# Altium schematic sheet [08]Debug Headers and Support.SchDoc
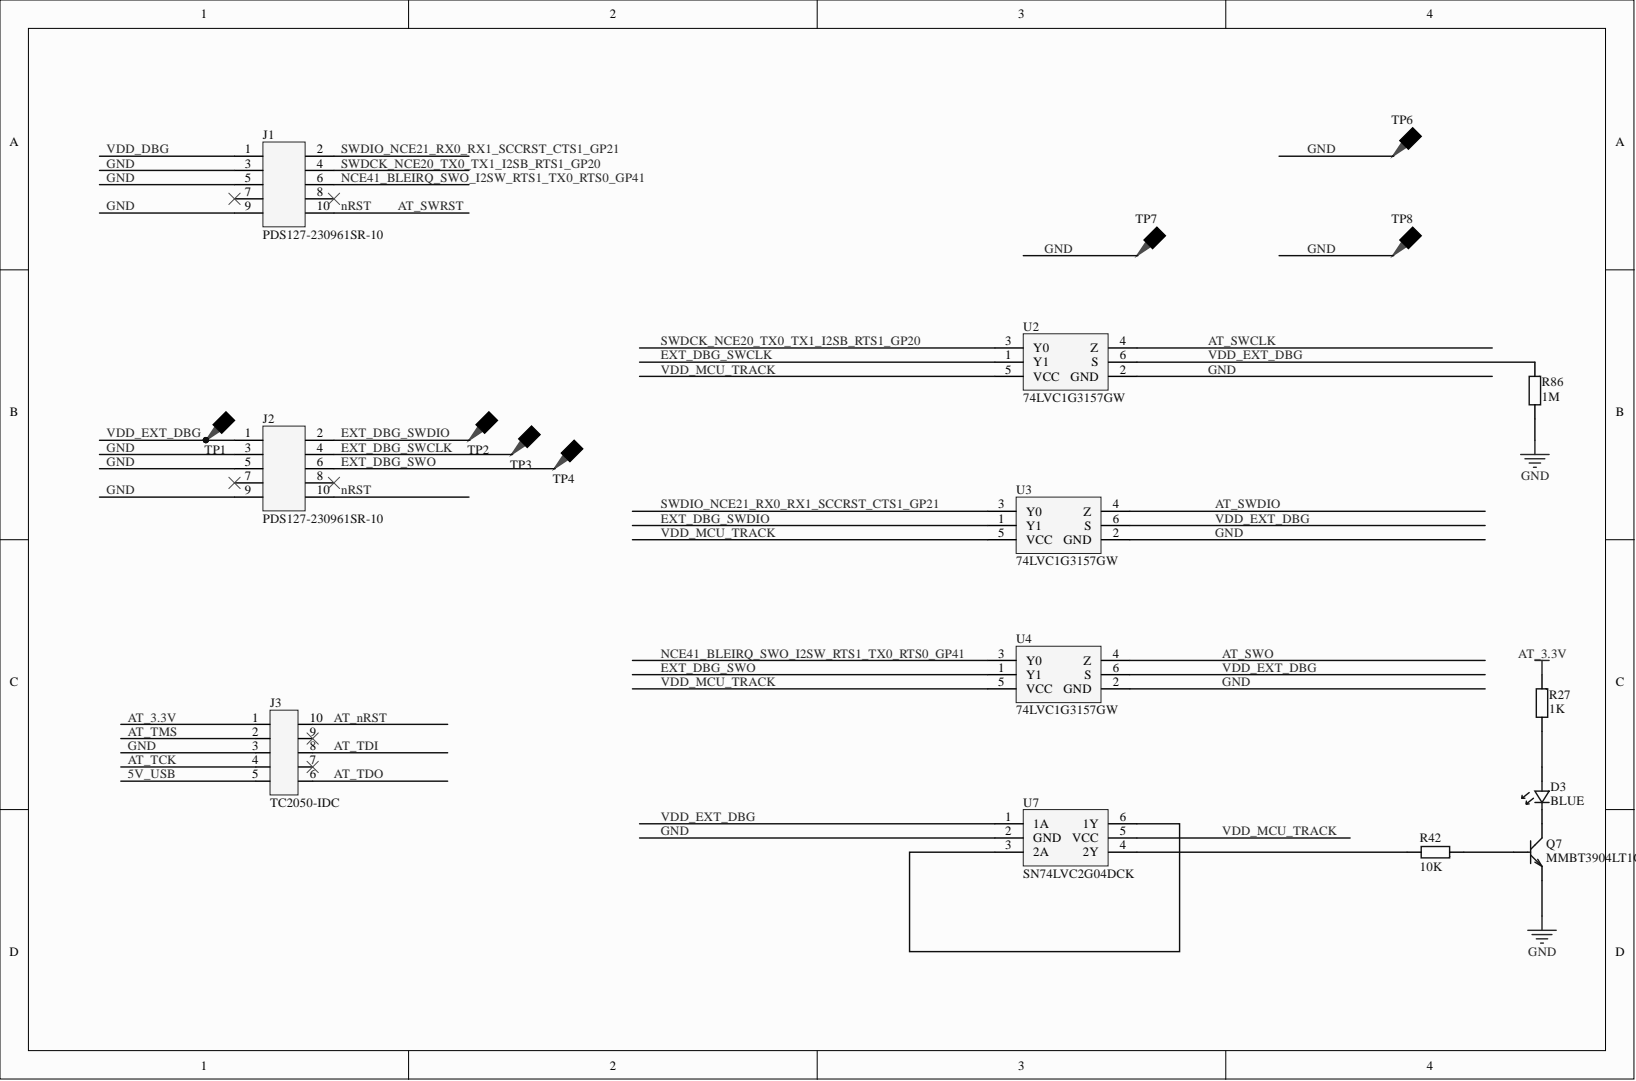
[diagram p0: [08]Debug Headers and Support.SchDoc]

## Parts
D3  BLUE  [LEDC1608L]
J1  PDS127-230961SR-10  [FTSH-105]
J2  PDS127-230961SR-10  [FTSH-105]
J3  TC2050-IDC  [TC2050-IDC]  (no BOM)
Q7  MMBT3904LT1G  [SOT23-3L]
R27  1K  [RESC1005L]
R42  10K  [RESC1005L]
R86  1M  [RESC1005L]
TP1  Test Point  [TP_TH_10_20]  (value not drawn)  (no BOM)
TP2  Test Point  [TP_TH_10_20]  (value not drawn)  (no BOM)
TP3  Test Point  [TP_TH_10_20]  (value not drawn)  (no BOM)
TP4  Test Point  [TP_TH_10_20]  (value not drawn)  (no BOM)
TP6  5016  [5016]  (value not drawn)
TP7  5016  [5016]  (value not drawn)
TP8  5016  [5016]  (value not drawn)
U2  74LVC1G3157GW  [SOT363-6L]
U3  74LVC1G3157GW  [SOT363-6L]
U4  74LVC1G3157GW  [SOT363-6L]
U7  SN74LVC2G04DCK  [SOT363-6L]

## Nets
5V_USB: J3.5
AT_3.3V: J3.1 R27.2
AT_nRST: J3.10
AT_SWCLK: U2.4(Z)
AT_SWDIO: U3.4(Z)
AT_SWO: U4.4(Z)
AT_SWRST: J1.10 J2.10
AT_TCK: J3.4
AT_TDI: J3.8
AT_TDO: J3.6
AT_TMS: J3.2
EXT_DBG_SWCLK: J2.4 TP3.1 U2.1(Y1)
EXT_DBG_SWDIO: J2.2 TP2.1 U3.1(Y1)
EXT_DBG_SWO: J2.6 TP4.1 U4.1(Y1)
GND: J1.3 J1.5 J1.9 J2.3 J2.5 J2.9 J3.3 Q7.2 R86.1 TP6.1 TP7.1 TP8.1 U2.2(GND) U3.2(GND) U4.2(GND) U7.2(GND)
NCE41_BLEIRQ_SWO_I2SW_RTS1_TX0_RTS0_GP41: J1.6 U4.3(Y0)
SWDCK_NCE20_TX0_TX1_I2SB_RTS1_GP20: J1.4 U2.3(Y0)
SWDIO_NCE21_RX0_RX1_SCCRST_CTS1_GP21: J1.2 U3.3(Y0)
VDD_DBG: J1.1
VDD_EXT_DBG: J2.1 R86.2 TP1.1 U2.6(S) U3.6(S) U4.6(S) U7.1(1A)
VDD_MCU_TRACK: U2.5(VCC) U3.5(VCC) U4.5(VCC) U7.5(VCC)
NetD3_1: D3.1 Q7.3
NetD3_2: D3.2 R27.1
NetQ7_1: Q7.1 R42.2
NetR42_1: R42.1 U7.4(2Y)
NetU7_3: U7.3(2A) U7.6(1Y)

Not connected: J1 7 8; J2 7 8; J3 7 9

## Library data of the parts
D3 = LED_SMD: Surface Mount LED; Component Kind: Standard; Library Name: Discrete.SchLib
J1 J2 = FTSH-105: 10 Positions Header, Unshrouded Connector 1.27mm SMD Gold; Component Kind: Standard; Library Name: Connector.SchLib
Q7 = TRAN_NPN: Surface Mount NPN Transistor; Component Kind: Standard; Library Name: Discrete.SchLib
R27 R42 R86 = RES_CHP: Surface Mount Resistor; Component Kind: Standard; Library Name: Discrete.SchLib
TP1-TP4 = TP: Description: Test Point; Library Name: Discrete.SchLib
TP6-TP8 = TP: Test Point; Component Kind: Standard; Library Name: Discrete.SchLib
U2-U4 = 74LVC1G3157GW: 2-channel analog multiplexer/demultiplexer; Component Kind: Standard; Library Name: IC.SchLib
U7 = 74LVC2G04: Dual Inverter; Component Kind: Standard; Library Name: IC.SchLib
J3 = TC2050-IDC: Library Name: Connector.SchLib
